# Altium schematic sheet TriPi_Beginners_HAT.SchDoc
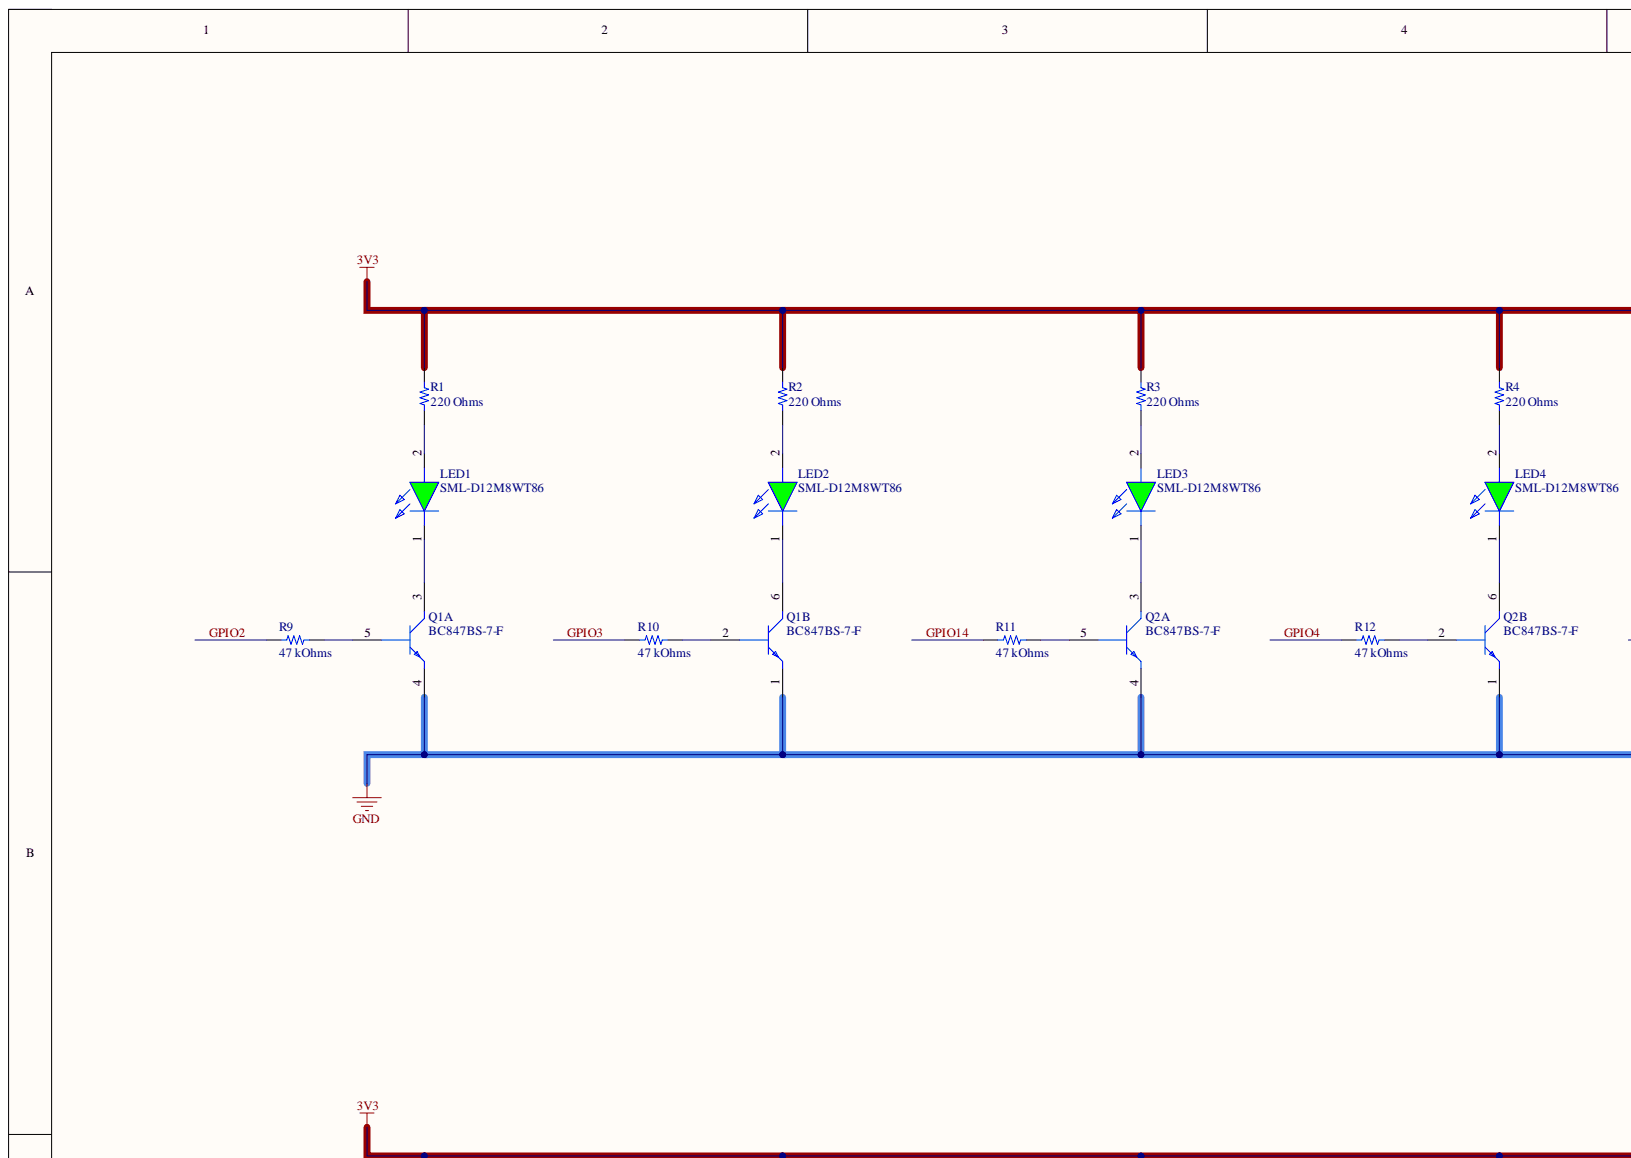
[diagram p0: TriPi_Beginners_HAT.SchDoc, top left]
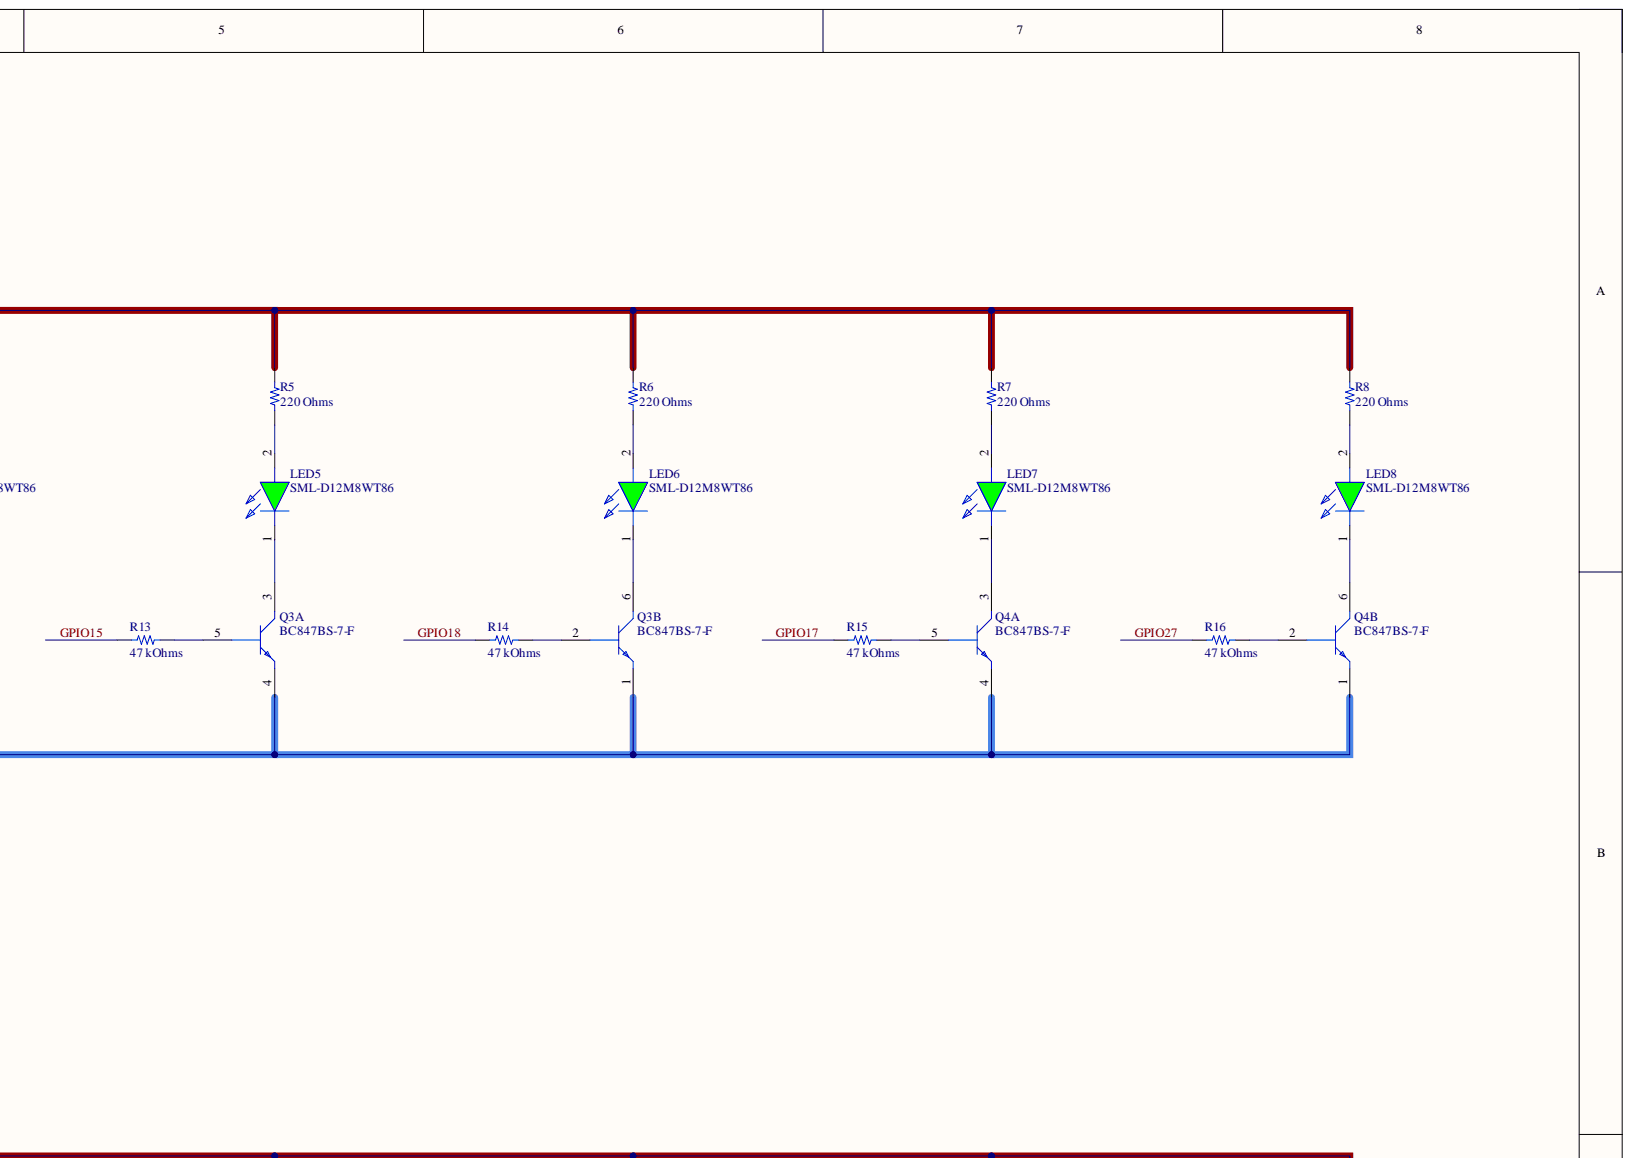
[diagram p1: TriPi_Beginners_HAT.SchDoc, top right]
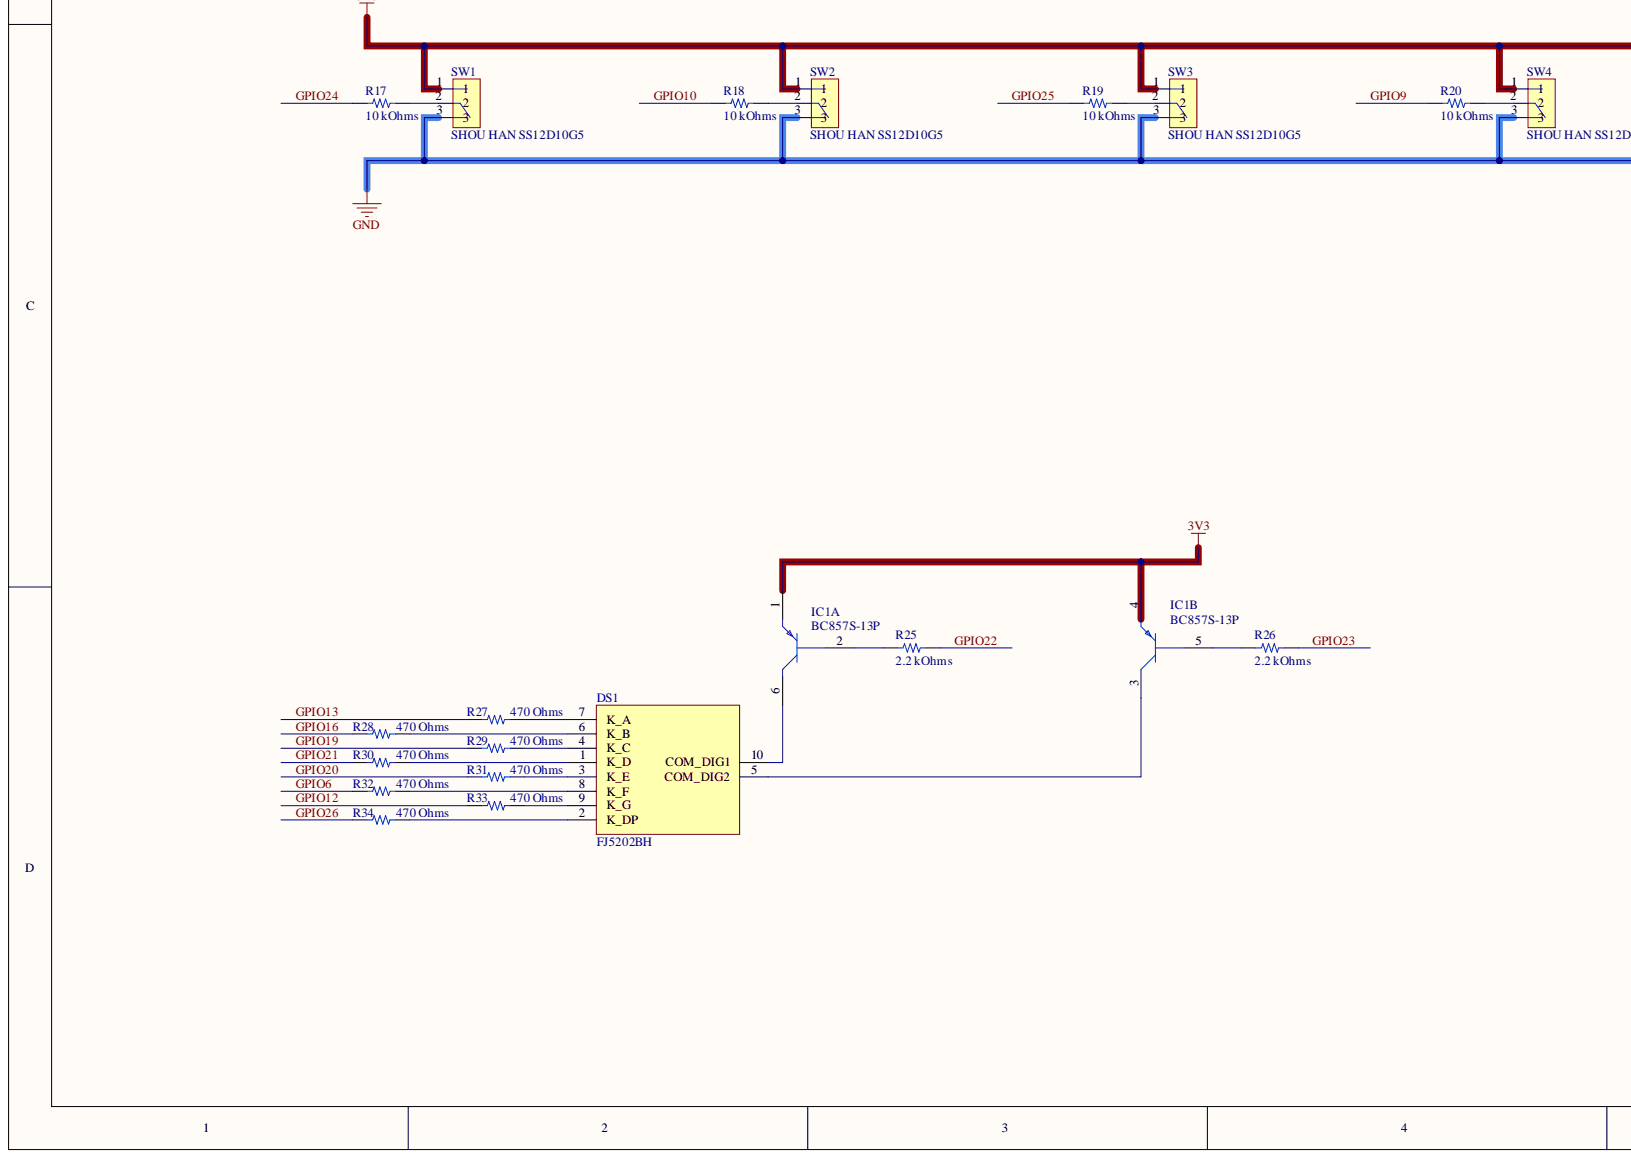
[diagram p2: TriPi_Beginners_HAT.SchDoc, bottom left]
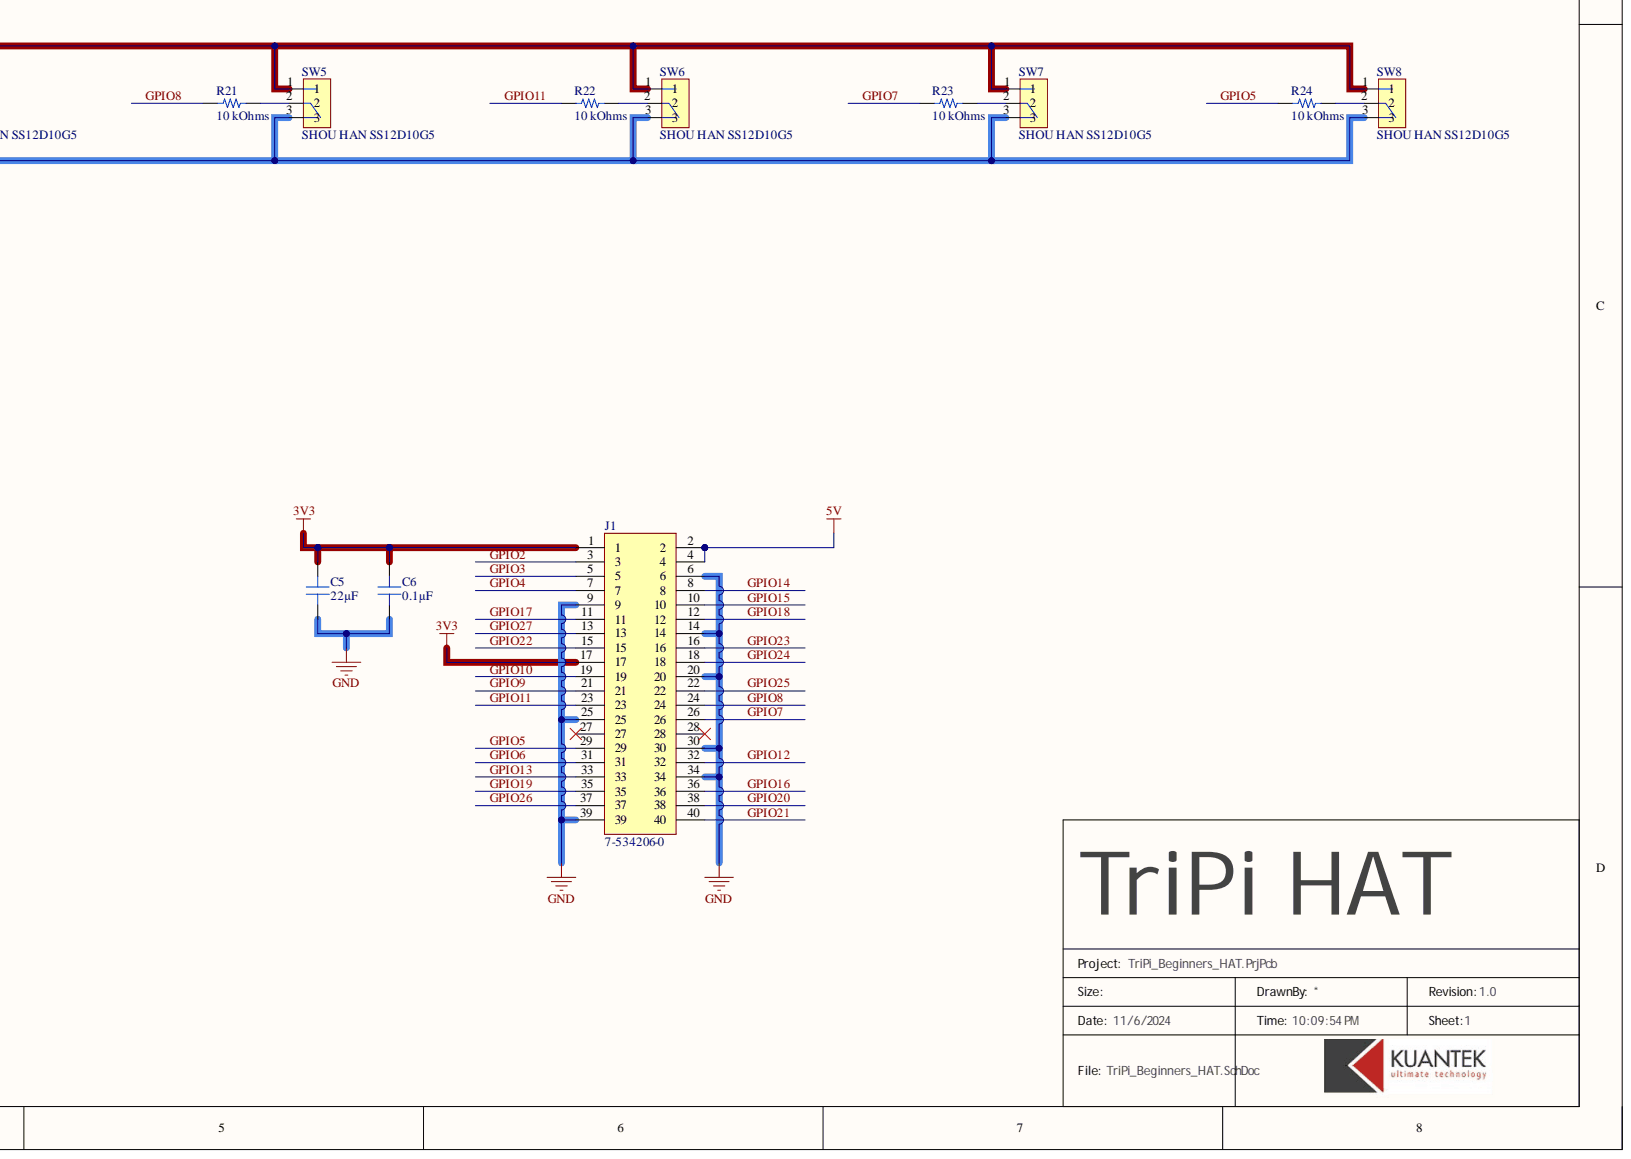
[diagram p3: TriPi_Beginners_HAT.SchDoc, bottom right]

## Parts
C5  22µF  [CAP 0805_2012]
C6  0.1µF  [CAP 0603_1608]
DS1  FJ5202BH  [FJ5202BH]
IC1  BC857S-13P  [SOT65P222X120-6N]  (parts A,B)
J1  7-534206-0  [TE_7-534206-0]
LED1  SML-D12M8WT86  [LEDM1620X150N]
LED2  SML-D12M8WT86  [LEDM1620X150N]
LED3  SML-D12M8WT86  [LEDM1620X150N]
LED4  SML-D12M8WT86  [LEDM1620X150N]
LED5  SML-D12M8WT86  [LEDM1620X150N]
LED6  SML-D12M8WT86  [LEDM1620X150N]
LED7  SML-D12M8WT86  [LEDM1620X150N]
LED8  SML-D12M8WT86  [LEDM1620X150N]
Q1  BC847BS-7-F  [SOT65P210X110-6N]  (parts A,B)
Q2  BC847BS-7-F  [SOT65P210X110-6N]  (parts A,B)
Q3  BC847BS-7-F  [SOT65P210X110-6N]  (parts A,B)
Q4  BC847BS-7-F  [SOT65P210X110-6N]  (parts A,B)
R1  220 Ohms  [RES 0603_1608]
R2  220 Ohms  [RES 0603_1608]
R3  220 Ohms  [RES 0603_1608]
R4  220 Ohms  [RES 0603_1608]
R5  220 Ohms  [RES 0603_1608]
R6  220 Ohms  [RES 0603_1608]
R7  220 Ohms  [RES 0603_1608]
R8  220 Ohms  [RES 0603_1608]
R9  47 kOhms  [RES 0603_1608]
R10  47 kOhms  [RES 0603_1608]
R11  47 kOhms  [RES 0603_1608]
R12  47 kOhms  [RES 0603_1608]
R13  47 kOhms  [RES 0603_1608]
R14  47 kOhms  [RES 0603_1608]
R15  47 kOhms  [RES 0603_1608]
R16  47 kOhms  [RES 0603_1608]
R17  10 kOhms  [RES 0603_1608]
R18  10 kOhms  [RES 0603_1608]
R19  10 kOhms  [RES 0603_1608]
R20  10 kOhms  [RES 0603_1608]
R21  10 kOhms  [RES 0603_1608]
R22  10 kOhms  [RES 0603_1608]
R23  10 kOhms  [RES 0603_1608]
R24  10 kOhms  [RES 0603_1608]
R25  2.2 kOhms  [RES 0603_1608]
R26  2.2 kOhms  [RES 0603_1608]
R27  470 Ohms  [RES 0603_1608]
R28  470 Ohms  [RES 0603_1608]
R29  470 Ohms  [RES 0603_1608]
R30  470 Ohms  [RES 0603_1608]
R31  470 Ohms  [RES 0603_1608]
R32  470 Ohms  [RES 0603_1608]
R33  470 Ohms  [RES 0603_1608]
R34  470 Ohms  [RES 0603_1608]
SW1  SHOU HAN SS12D10G5  [SHOU HAN SS12D10G5]
SW2  SHOU HAN SS12D10G5  [SHOU HAN SS12D10G5]
SW3  SHOU HAN SS12D10G5  [SHOU HAN SS12D10G5]
SW4  SHOU HAN SS12D10G5  [SHOU HAN SS12D10G5]
SW5  SHOU HAN SS12D10G5  [SHOU HAN SS12D10G5]
SW6  SHOU HAN SS12D10G5  [SHOU HAN SS12D10G5]
SW7  SHOU HAN SS12D10G5  [SHOU HAN SS12D10G5]
SW8  SHOU HAN SS12D10G5  [SHOU HAN SS12D10G5]

## Nets
3V3: C5.1 C6.2 IC1.1 IC1.4 J1.1 J1.17 R1.1 R2.1 R3.1 R4.1 R5.1 R6.1 R7.1 R8.1 SW1.1 SW2.1 SW3.1 SW4.1 SW5.1 SW6.1 SW7.1 SW8.1
5V: J1.2 J1.4
GND: C5.2 C6.1 J1.6 J1.9 J1.14 J1.20 J1.25 J1.30 J1.34 J1.39 Q1.1 Q1.4 Q2.1 Q2.4 Q3.1 Q3.4 Q4.1 Q4.4 SW1.3 SW2.3 SW3.3 SW4.3 SW5.3 SW6.3 SW7.3 SW8.3
GPIO2: J1.3 R9.2
GPIO3: J1.5 R10.2
GPIO4: J1.7 R12.2
GPIO5: J1.29 R24.2
GPIO6: J1.31 R32.1
GPIO7: J1.26 R23.2
GPIO8: J1.24 R21.2
GPIO9: J1.21 R20.2
GPIO10: J1.19 R18.2
GPIO11: J1.23 R22.2
GPIO12: J1.32 R33.1
GPIO13: J1.33 R27.1
GPIO14: J1.8 R11.2
GPIO15: J1.10 R13.2
GPIO16: J1.36 R28.1
GPIO17: J1.11 R15.2
GPIO18: J1.12 R14.2
GPIO19: J1.35 R29.1
GPIO20: J1.38 R31.1
GPIO21: J1.40 R30.1
GPIO22: J1.15 R25.2
GPIO23: J1.16 R26.2
GPIO24: J1.18 R17.2
GPIO25: J1.22 R19.2
GPIO26: J1.37 R34.1
GPIO27: J1.13 R16.2
NetDS1_1: DS1.1(K_D) R30.2
NetDS1_2: DS1.2(K_DP) R34.2
NetDS1_3: DS1.3(K_E) R31.2
NetDS1_4: DS1.4(K_C) R29.2
NetDS1_5: DS1.5(COM_DIG2) IC1.3
NetDS1_6: DS1.6(K_B) R28.2
NetDS1_7: DS1.7(K_A) R27.2
NetDS1_8: DS1.8(K_F) R32.2
NetDS1_9: DS1.9(K_G) R33.2
NetDS1_10: DS1.10(COM_DIG1) IC1.6
NetIC1_2: IC1.2 R25.1
NetIC1_5: IC1.5 R26.1
NetLED1_1: LED1.1 Q1.3
NetLED1_2: LED1.2 R1.2
NetLED2_1: LED2.1 Q1.6
NetLED2_2: LED2.2 R2.2
NetLED3_1: LED3.1 Q2.3
NetLED3_2: LED3.2 R3.2
NetLED4_1: LED4.1 Q2.6
NetLED4_2: LED4.2 R4.2
NetLED5_1: LED5.1 Q3.3
NetLED5_2: LED5.2 R5.2
NetLED6_1: LED6.1 Q3.6
NetLED6_2: LED6.2 R6.2
NetLED7_1: LED7.1 Q4.3
NetLED7_2: LED7.2 R7.2
NetLED8_1: LED8.1 Q4.6
NetLED8_2: LED8.2 R8.2
NetQ1_2: Q1.2 R10.1
NetQ1_5: Q1.5 R9.1
NetQ2_2: Q2.2 R12.1
NetQ2_5: Q2.5 R11.1
NetQ3_2: Q3.2 R14.1
NetQ3_5: Q3.5 R13.1
NetQ4_2: Q4.2 R16.1
NetQ4_5: Q4.5 R15.1
NetR17_1: R17.1 SW1.2
NetR18_1: R18.1 SW2.2
NetR19_1: R19.1 SW3.2
NetR20_1: R20.1 SW4.2
NetR21_1: R21.1 SW5.2
NetR22_1: R22.1 SW6.2
NetR23_1: R23.1 SW7.2
NetR24_1: R24.1 SW8.2

Not connected: J1 27 28

## Library data of the parts
C5 = CL21A226KOQNNNG: CAP CER 22UF 16V X5R 0805; Manufacturer: Samsung Electro-Mechanics; Database Table Name: Capacitors - Ceramic - 0805; Tolerance: ±10%; Applications: General Purpose; Capacitance: 22µF; Component Kind: Standard; ComponentLink1Description: Datasheet; ComponentLink1URL: //media.digikey.com/pdf/Data%20Sheets/Samsung%20PDFs/CL21A226KOQNNNG_Spec.pdf; ComponentLink2Description: DigiKey Link; ComponentLink2URL: http://digikey.com/product-detail/en/samsung-electro-mechanics/CL21A226KOQNNNG/1276-7092-1-ND/8021509; Device Package: 0805 (2012 Metric); LastUpdated: 2020-09-02 01:04:40.713000; Library Name: Database_Libs1.DbLib; Lifecycle Status: Active; Minimum Order: 1; Mounting Type: Surface Mount, MLCC; Operating Temperature: -55°C ~ 85°C; Package / Case: 0805 (2012 Metric); Packaging: TapeAndReel; Part Number: CL21A226KOQNNNG; Part Status: Active; PartId: 3000; Price: 0.09; Series: CL; Size / Dimension: 0.079 L x 0.049 W (2.00mm x 1.25mm); Supplier 1: DigiKey; Supplier Part Number 1: 1276-7092-1-ND; Temperature Coefficient: X5R; Thickness (Max): 0.055 (1.40mm); Value: 22µF; Voltage - Rated: 16V
C6 = C0603X104M3RAC7867: CAP CER 0.1UF 25V X7R 0603; Manufacturer: KEMET; Database Table Name: Capacitors - Ceramic - 0603; Tolerance: ±20%; Applications: Boardflex Sensitive; Capacitance: 0.1µF; Component Kind: Standard; ComponentLink1Description: Datasheet; ComponentLink1URL: https://api.kemet.com/component-edge/download/datasheet/C0603X104M3RACTU.pdf; ComponentLink2Description: DigiKey Link; ComponentLink2URL: http://digikey.com/product-detail/en/kemet/C0603X104M3RAC7867/399-13148-1-ND/5879302; Device Package: 0603 (1608 Metric); Features: Soft Termination; LastUpdated: 2020-09-02 01:03:35.190000; Library Name: Database_Libs1.DbLib; Lifecycle Status: Active; Minimum Order: 1; Mounting Type: Surface Mount, MLCC; Operating Temperature: -55°C ~ 125°C; Package / Case: 0603 (1608 Metric); Packaging: TapeAndReel; Part Number: C0603X104M3RAC7867; Part Status: Active; PartId: 2484; Price: 0.08; Series: SMD Comm X7R Flex; Size / Dimension: 0.063 L x 0.031 W (1.60mm x 0.80mm); Supplier 1: DigiKey; Supplier Part Number 1: 399-13148-1-ND; Temperature Coefficient: X7R; Thickness (Max): 0.034 (0.87mm); Value: 0.1µF; Voltage - Rated: 25V
DS1 = FJ5202BH: Display; Manufacturer_Name: Shenzhen Zhihao Elec; Component Kind: Standard; Datasheet Link: https://datasheet.lcsc.com/lcsc/1809191825_Shenzhen-Zhihao-Elec-FJ5202BH_C14406.pdf; Height: 8mm; Library Name: SamacSys.SchLib; Manufacturer_Part_Number: FJ5202BH
IC1 = BC857S-13P: Integrated Circuit; Manufacturer_Name: MCC; Component Kind: Standard; Datasheet Link: https://www.mccsemi.com/pdf/Products/BC857S(SOT-363).pdf; Height: 1.2mm; Library Name: SamacSys.SchLib; Manufacturer_Part_Number: BC857S-13P
J1 = 7-534206-0: Connector Socket Strip Receptacle 40 Position 2.54mm Solder Straight Through Hole Gang Of Tubes; Centerline_Pitch: 2.54 mm[.1 in]; MF: TE Connectivity; Number_of_Positions: 40; Availability: Unavailable; Component Kind: Standard; EU_RoHS_Compliance: Compliant; Library Name: SamacSys.SchLib; MP: 7-534206-0; Package: None; Price: None; Product_Type: Connector; SnapEDA_Link: https://www.snapeda.com/parts/7-534206-0/TE%20Connectivity%20AMP%20Connectors/view-part/1924012/?ref=snap; TE_purchase_URL: https://www.te.com/usa-en/product-7-534206-0.html?te_bu=Cor&te_type=disp&te_campaign=seda_glo_cor-seda-global-disp-prtnr-fy19-seda-model-bom-cta_sma-317_1&elqCampaignId=32493
LED1-LED8 = SML-D12M8WT86: LED; Manufacturer_Name: ROHM Semiconductor; Arrow Part Number: SML-D12M8WT86; Arrow Price/Stock: http://www.arrow.com/en/products/sml-d12m8wt86/rohm-semiconductor; Component Kind: Standard; Datasheet Link: https://datasheet.datasheetarchive.com/originals/distributors/Datasheets_SAMA/f235664866caa647598714bb71411137.pdf; Height: 1.5mm; Library Name: SamacSys.SchLib; Manufacturer_Part_Number: SML-D12M8WT86; Mouser Part Number: 755-SML-D12M8WT86; Mouser Price/Stock: https://www.mouser.co.uk/ProductDetail/ROHM-Semiconductor/SML-D12M8WT86?qs=4kLU8WoGk0vGWtDreJ93zQ%3D%3D
Q1-Q4 = BC847BS-7-F: Transistor BJT NPN; Manufacturer_Name: Diodes Incorporated; Arrow Part Number: BC847BS-7-F; Arrow Price/Stock: https://www.arrow.com/en/products/bc847bs-7-f/diodes-incorporated?region=nac; Component Kind: Standard; Datasheet Link: https://www.diodes.com/assets/Datasheets/ds30222.pdf; Height: 1.1mm; Library Name: SamacSys.SchLib; Manufacturer_Part_Number: BC847BS-7-F; Mouser Part Number: 621-BC847BS-7-F; Mouser Price/Stock: https://www.mouser.co.uk/ProductDetail/Diodes-Incorporated/BC847BS-7-F?qs=rGAXPo9uwV3sf9v%2FHEcaVA%3D%3D
R1-R8 = CRCW0603220RFKEAC: RES SMD 220 OHM 1% 1/10W 0603; Manufacturer: Vishay Dale; Database Table Name: Resistors - Surface Mount - 0603; Power (Watts): 0.1W, 1/10W; Temperature Coefficient: ±100ppm/°C; Component Kind: Standard; ComponentLink1Description: Datasheet; ComponentLink1URL: http://www.vishay.com/docs/28773/crcwce3.pdf; ComponentLink2Description: DigiKey Link; ComponentLink2URL: http://digikey.com/product-detail/en/vishay-dale/CRCW0603220RFKEAC/541-4047-1-ND/7928730; Composition: Thick Film; Device Package: 0603 (1608 Metric); Height - Seated (Max): 0.022 (0.55mm); LastUpdated: 2020-09-02 01:10:08.467000; Library Name: Database_Libs1.DbLib; Lifecycle Status: Active; Minimum Order: 1; Number of Terminations: 2; Operating Temperature: -55°C ~ 155°C; Package / Case: 0603 (1608 Metric); Packaging: CutTape; Part Number: CRCW0603220RFKEAC; Part Status: Active; PartId: 12600; Price: 0.10; Resistance: 220 Ohms; Series: CRCW-C; Supplier 1: DigiKey; Supplier Device Package: 0603; Supplier Part Number 1: 541-4047-1-ND; Tolerance: ±1%; Value: 220 Ohms
R9-R16 = RT0603FRE0747KL: RES SMD 47K OHM 1% 1/10W 0603; Manufacturer: Yageo; Database Table Name: Resistors - Surface Mount - 0603; Power (Watts): 0.1W, 1/10W; Temperature Coefficient: ±50ppm/°C; Component Kind: Standard; ComponentLink1Description: Datasheet; ComponentLink1URL: https://www.yageo.com/upload/media/product/productsearch/datasheet/rchip/PYu-RT_1-to-0.01_RoHS_L_11.pdf; ComponentLink2Description: DigiKey Link; ComponentLink2URL: http://digikey.com/product-detail/en/yageo/RT0603FRE0747KL/YAG3355CT-ND/5418060; Composition: Thin Film; Device Package: 0603 (1608 Metric); Height - Seated (Max): 0.022 (0.55mm); LastUpdated: 2020-09-02 01:10:23.053000; Library Name: Database_Libs1.DbLib; Lifecycle Status: Active; Minimum Order: 1; Number of Terminations: 2; Operating Temperature: -55°C ~ 155°C; Package / Case: 0603 (1608 Metric); Packaging: TapeAndReel; Part Number: RT0603FRE0747KL; Part Status: Active; PartId: 5674; Price: 0.01; Resistance: 47 kOhms; Series: RT; Size / Dimension: 0.063 L x 0.031 W (1.60mm x 0.80mm); Supplier 1: DigiKey; Supplier Device Package: 0603; Supplier Part Number 1: YAG3355CT-ND; Tolerance: ±1%; Value: 47 kOhms
R17-R24 = RT0603FRE0710KL: RES SMD 10K OHM 1% 1/10W 0603; Manufacturer: Yageo; Database Table Name: Resistors - Surface Mount - 0603; Power (Watts): 0.1W, 1/10W; Temperature Coefficient: ±50ppm/°C; Component Kind: Standard; ComponentLink1Description: Datasheet; ComponentLink1URL: https://www.yageo.com/upload/media/product/productsearch/datasheet/rchip/PYu-RT_1-to-0.01_RoHS_L_11.pdf; ComponentLink2Description: DigiKey Link; ComponentLink2URL: http://digikey.com/product-detail/en/yageo/RT0603FRE0710KL/YAG2321CT-ND/5252435; Composition: Thin Film; Device Package: 0603 (1608 Metric); Height - Seated (Max): 0.022 (0.55mm); LastUpdated: 2020-09-02 01:10:23.060000; Library Name: Database_Libs1.DbLib; Lifecycle Status: Active; Minimum Order: 1; Number of Terminations: 2; Operating Temperature: -55°C ~ 155°C; Package / Case: 0603 (1608 Metric); Packaging: TapeAndReel; Part Number: RT0603FRE0710KL; Part Status: Active; PartId: 5671; Price: 0.01; Resistance: 10 kOhms; Series: RT; Size / Dimension: 0.063 L x 0.031 W (1.60mm x 0.80mm); Supplier 1: DigiKey; Supplier Device Package: 0603; Supplier Part Number 1: YAG2321CT-ND; Tolerance: ±1%; Value: 10 kOhms
R25 R26 = ERJ-3EKF2201V: RES SMD 2.2K OHM 1% 1/10W 0603; Manufacturer: Panasonic Electronic Components; Database Table Name: Resistors - Surface Mount - 0603; Power (Watts): 0.1W, 1/10W; Temperature Coefficient: ±100ppm/°C; Component Kind: Standard; ComponentLink1Description: Datasheet; ComponentLink1URL: https://industrial.panasonic.com/cdbs/www-data/pdf/RDA0000/AOA0000C304.pdf; ComponentLink2Description: DigiKey Link; ComponentLink2URL: https://www.digikey.com/en/products/detail/panasonic-electronic-components/ERJ-3EKF2201V/1024416; Composition: Thick Film; Device Package: 0603 (1608 Metric); Features: Automotive AEC-Q200; Height - Seated (Max): 0.022" (0.55mm); LastUpdated: 2/9/2024 08:43:34 PM; Library Name: Celestial Altium Library - altium_library.DbLib; Lifecycle Status: Active; Minimum Order: 1; Number of Terminations: 2; Operating Temperature: -55°C ~ 155°C; Package / Case: 0603 (1608 Metric); Packaging: TapeAndReel; Part Number: ERJ-3EKF2201V; Part Status: Active; PartId: 5643; Price: 0.01; Ratings: AEC-Q200; Resistance: 2.2 kOhms; Series: ERJ-3EK; Size / Dimension: 0.063" L x 0.031" W (1.60mm x 0.80mm); Supplier 1: DigiKey; Supplier Device Package: 0603; Supplier Part Number 1: P2.20KHCT-ND; Tolerance: ±1%; Value: 2.2 kOhms
R27-R34 = ERJ-3EKF4700V: RES SMD 470 OHM 1% 1/10W 0603; Manufacturer: Panasonic Electronic Components; Database Table Name: Resistors - Surface Mount - 0603; Power (Watts): 0.1W, 1/10W; Temperature Coefficient: ±100ppm/°C; Component Kind: Standard; ComponentLink1Description: Datasheet; ComponentLink1URL: https://industrial.panasonic.com/cdbs/www-data/pdf/RDA0000/AOA0000C304.pdf; ComponentLink2Description: DigiKey Link; ComponentLink2URL: https://www.digikey.com/en/products/detail/panasonic-electronic-components/ERJ-3EKF4700V/1746417; Composition: Thick Film; Device Package: 0603 (1608 Metric); Features: Automotive AEC-Q200; Height - Seated (Max): 0.022" (0.55mm); LastUpdated: 2/9/2024 08:43:34 PM; Library Name: Celestial Altium Library - altium_library.DbLib; Lifecycle Status: Active; Minimum Order: 1; Number of Terminations: 2; Operating Temperature: -55°C ~ 155°C; Package / Case: 0603 (1608 Metric); Packaging: TapeAndReel; Part Number: ERJ-3EKF4700V; Part Status: Active; PartId: 5664; Price: 0.01; Ratings: AEC-Q200; Resistance: 470 Ohms; Series: ERJ-3EK; Size / Dimension: 0.063" L x 0.031" W (1.60mm x 0.80mm); Supplier 1: DigiKey; Supplier Device Package: 0603; Supplier Part Number 1: P470HCT-ND; Tolerance: ±1%; Value: 470 Ohms
SW1-SW8 = SHOU HAN SS12D10G5: Straight 2A SPDT 125V 3Thousand times Plugin Slide Switches ROHS; Component Kind: Standard; Library Name: SamacSys.SchLib
